# Altium schematic sheet Driver_Led_7seg_matrix.SchDoc(Driver_Led_7seg_matrix)
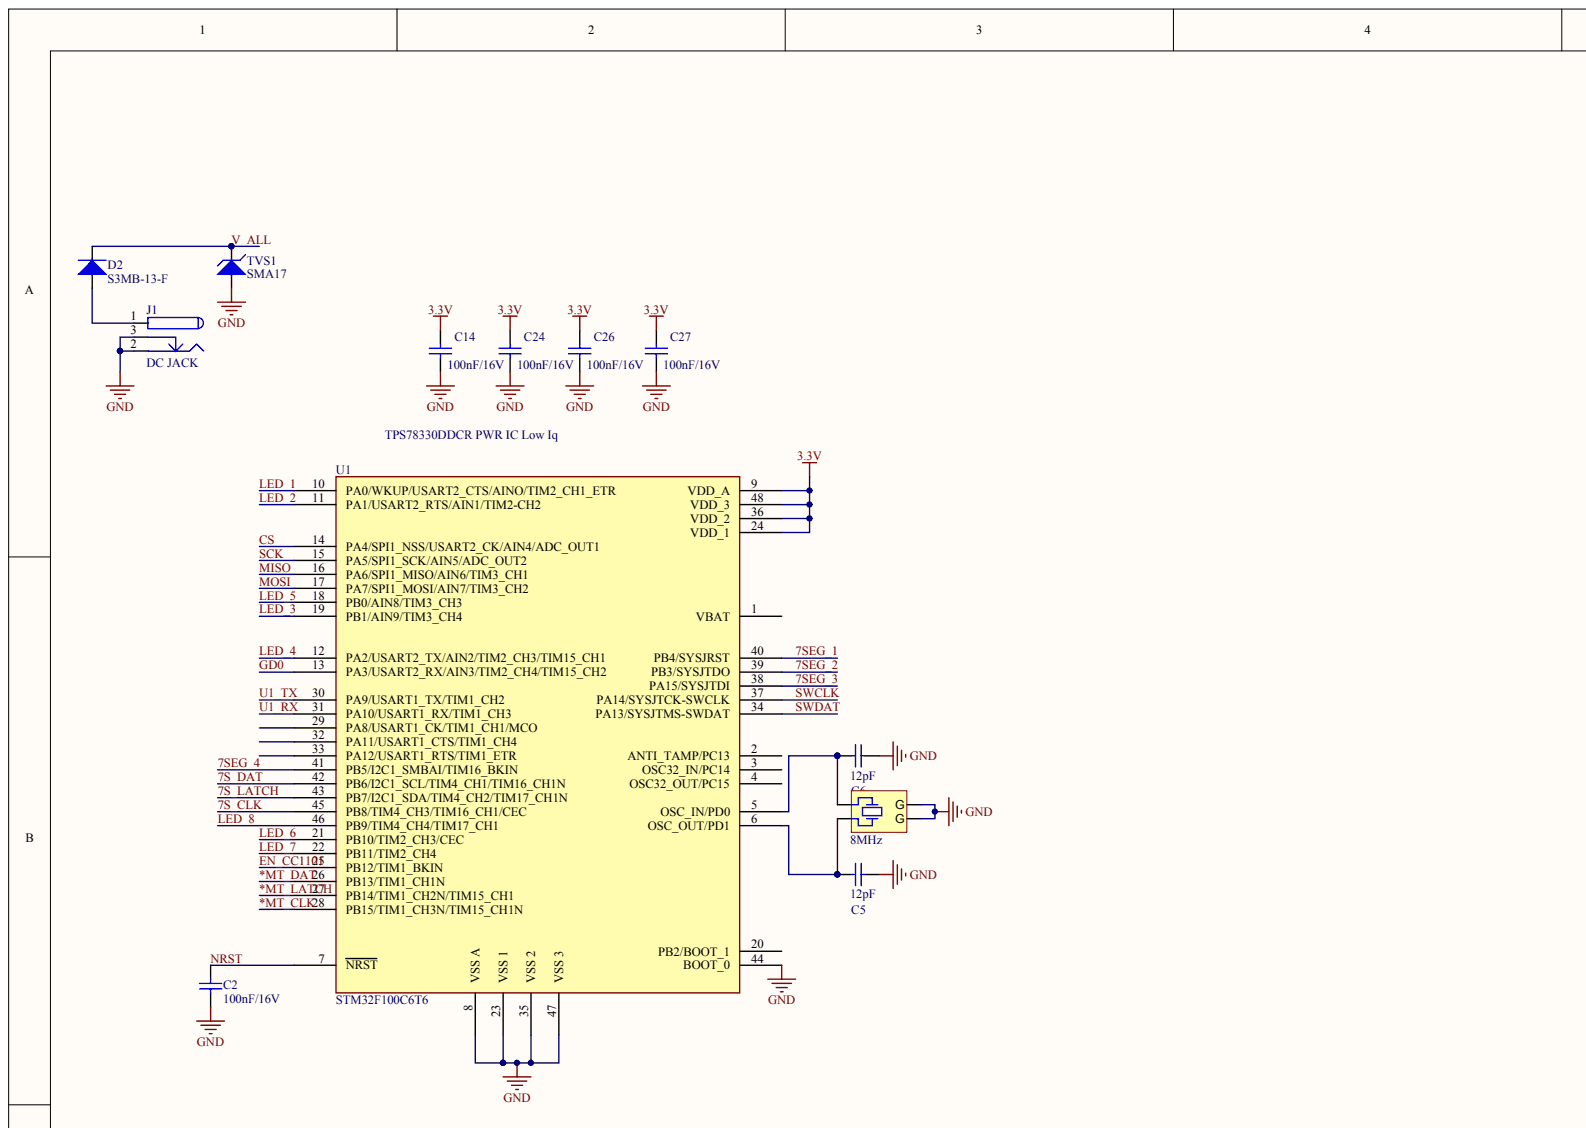
[diagram p0: Driver_Led_7seg_matrix.SchDoc, top left]
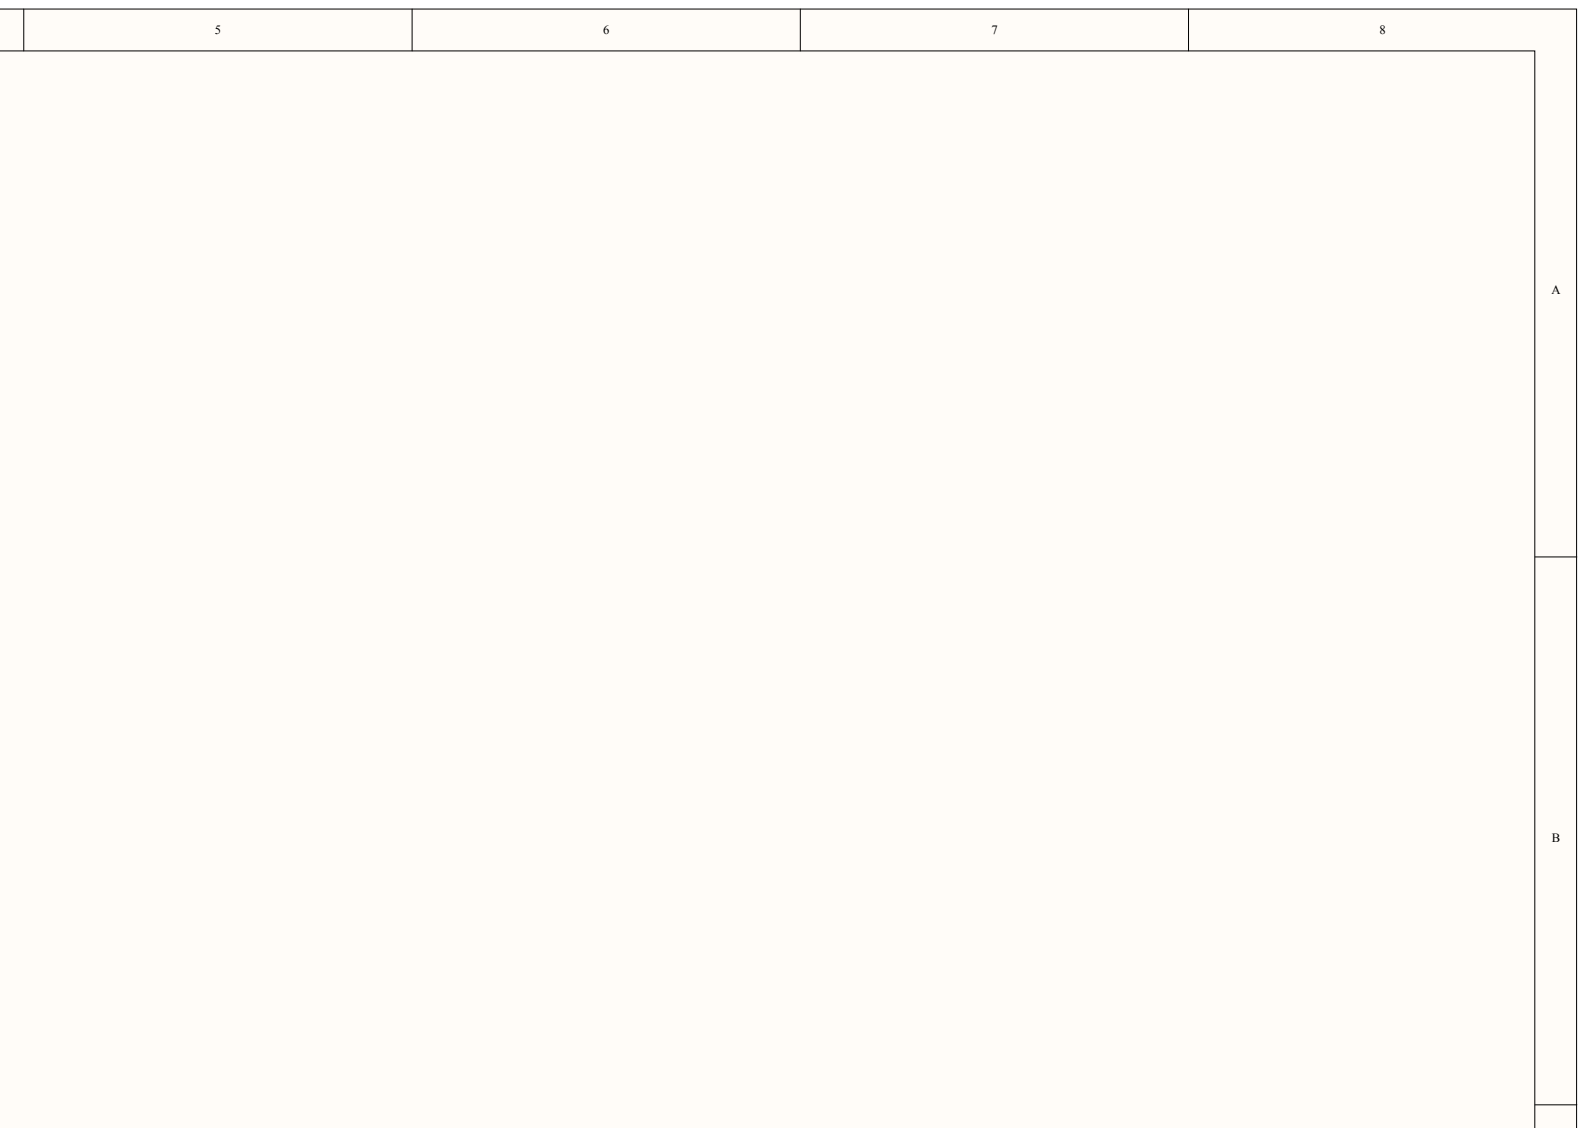
[diagram p1: Driver_Led_7seg_matrix.SchDoc, top right]
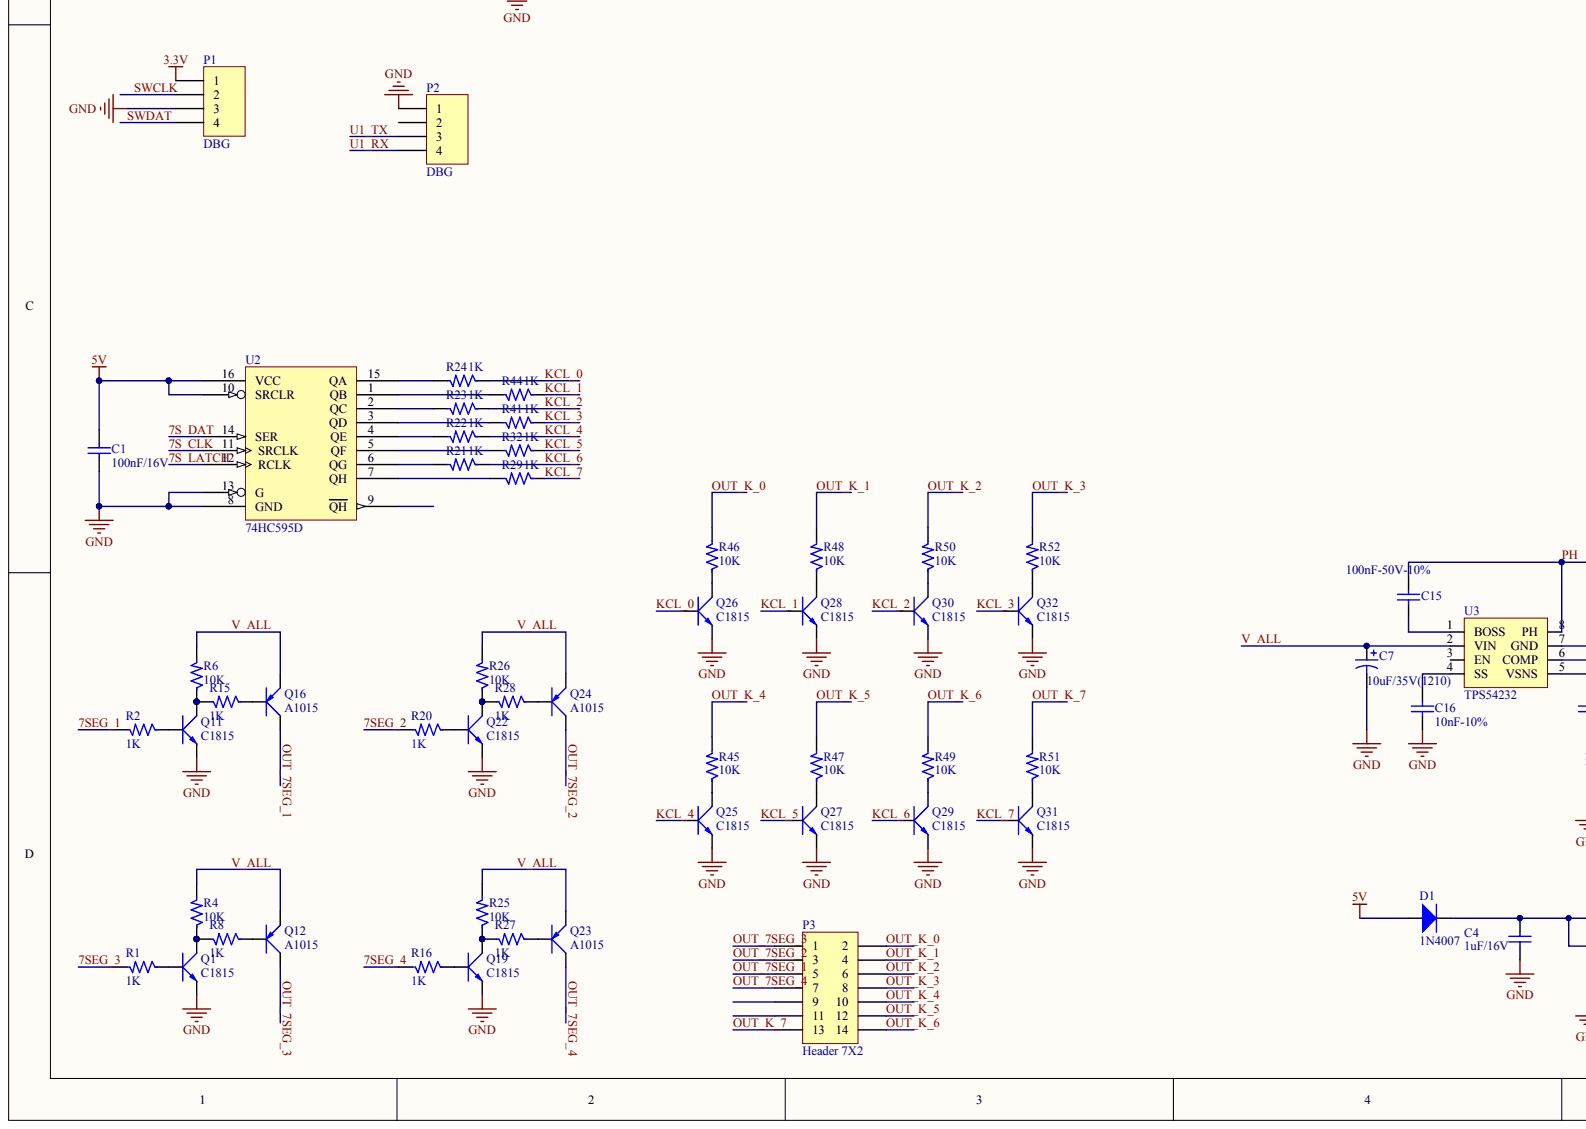
[diagram p2: Driver_Led_7seg_matrix.SchDoc, bottom left]
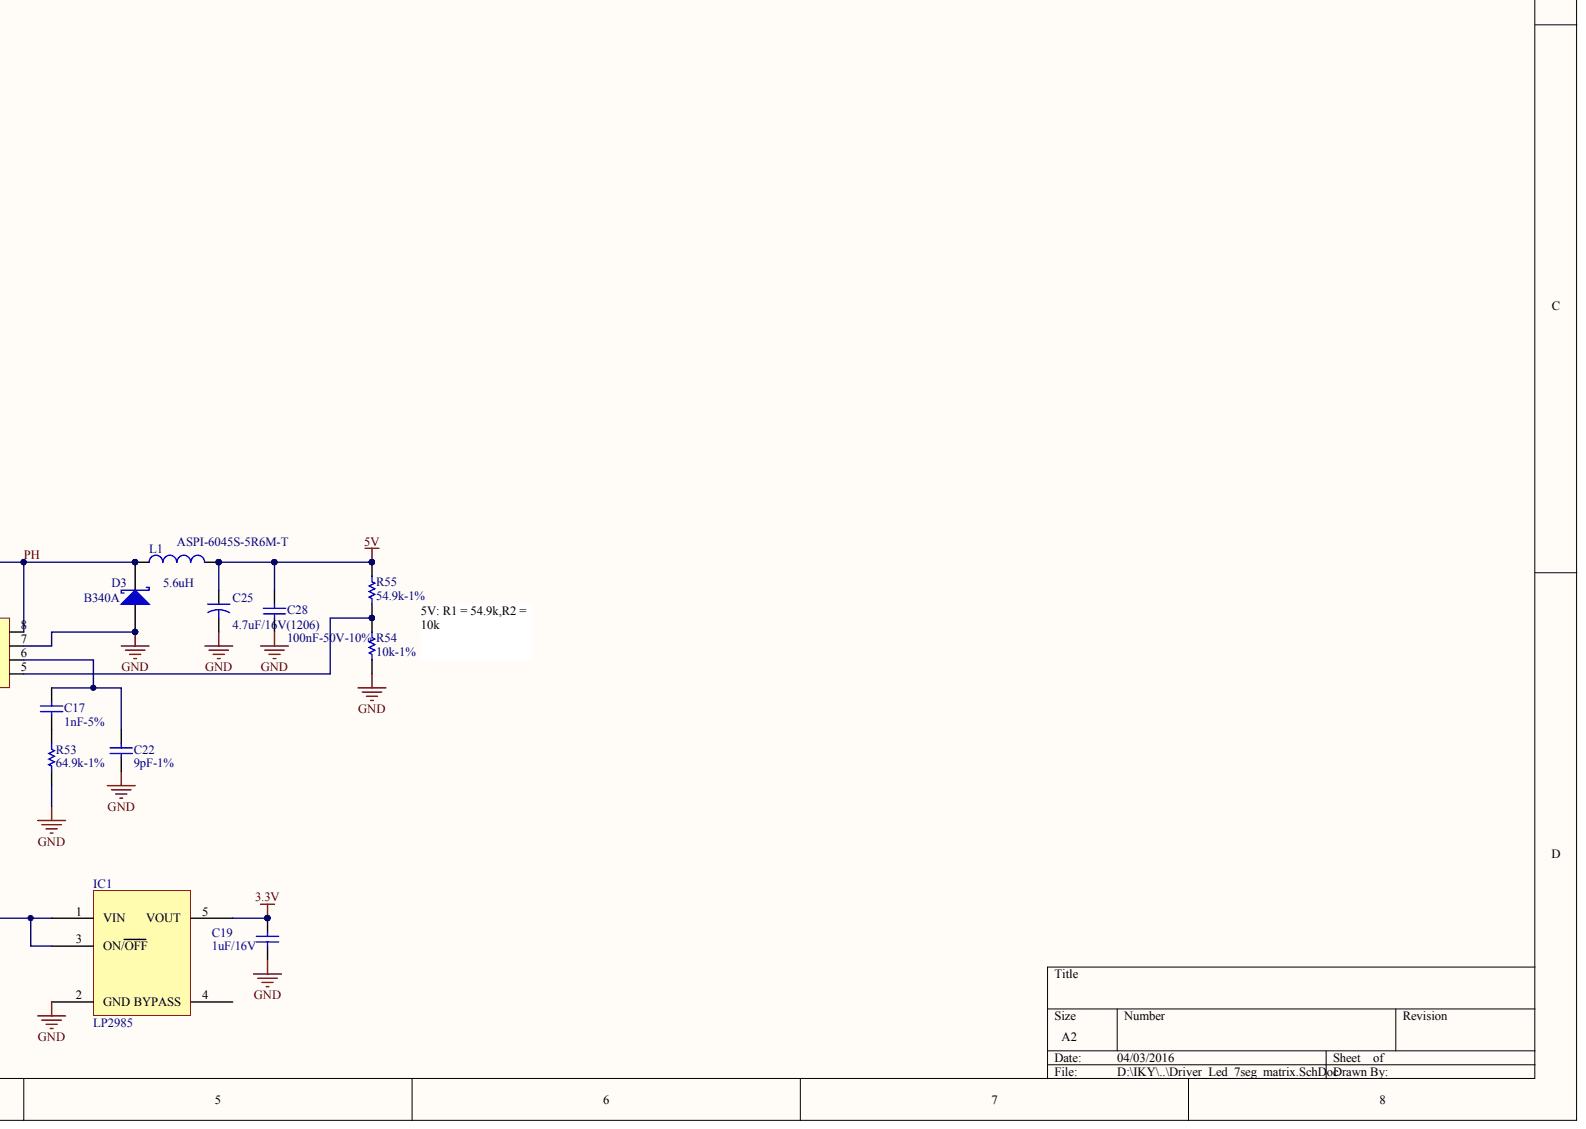
[diagram p3: Driver_Led_7seg_matrix.SchDoc, bottom right]

## Parts
C1  100nF/16V
C2  100nF/16V
C4  1uF/16V
C5  12pF
C6  12pF
C7  10uF/35V(1210)
C14  100nF/16V
C15  100nF  value 100nF-50V-10%
C16  10nF-10%
C17  1nF-5%
C19  1uF/16V
C22  9pF-1%
C24  100nF/16V
C25  4.7uF  value 4.7uF/16V(1206)
C26  100nF/16V
C27  100nF/16V
C28  100nF  value 100nF-50V-10%
D1  1N4007
D2  S3MB-13-F
D3  B340A
IC1  LP2985
J1  DC JACK
L1  ASPI-6045S-5R6M-T  value 5.6uH
P1  DBG
P2  DBG
P3  Header 7X2
Q1  C1815
Q11  C1815
Q12  A1015
Q16  A1015
Q19  C1815
Q22  C1815
Q23  A1015
Q24  A1015
Q25  C1815
Q26  C1815
Q27  C1815
Q28  C1815
Q29  C1815
Q30  C1815
Q31  C1815
Q32  C1815
R1  1K
R2  1K
R4  10K
R6  10K
R8  value 10K  (hidden comment: 1K)
R15  1K  value 10K
R16  1K
R20  1K
R21  1K  (value not drawn)
R22  1K  (value not drawn)
R23  1K  (value not drawn)
R24  1K  (value not drawn)
R25  10K
R26  10K
R27  1K  value 10K
R28  1K  value 10K
R29  1K  (value not drawn)
R32  1K  (value not drawn)
R41  1K  (value not drawn)
R44  1K  (value not drawn)
R45  10K
R46  10K
R47  10K
R48  10K
R49  10K
R50  10K
R51  10K
R52  10K
R53  64.9k-1%
R54  10k-1%
R55  54.9k-1%
TVS1  SMA17
U1  STM32F100C6T6
U2  74HC595D
U3  TPS54232
Y1  8MHz

## Nets
3.3V: C14.1 C19.1 C24.1 C26.1 C27.1 IC1.5(VOUT) P1.1 U1.9(VDD_A) U1.24(VDD_1) U1.36(VDD_2) U1.48(VDD_3)
5V: C1.1 C25.1 C28.2 D1.1 L1.2 R55.2 U2.10(SRCLR) U2.16(VCC)
GND: C1.2 C2.2 C4.2 C5.2 C6.2 C7.2 C14.2 C16.1 C19.2 C22.1 C24.2 C25.2 C26.2 C27.2 C28.1 D3.1 IC1.2(GND) J1.2 J1.3 P1.3 P2.1 Q1.2 Q11.2 Q19.2 Q22.2 Q25.2 Q26.2 Q27.2 Q28.2 Q29.2 Q30.2 Q31.2 Q32.2 R53.1 R54.1 TVS1.1 U1.8(VSS_A) U1.23(VSS_1) U1.35(VSS_2) U1.44(BOOT_0) U1.47(VSS_3) U2.8(GND) U2.13(G) U3.7(GND) Y1.2(G) Y1.4(G)
7S_CLK: U1.45(PB8/TIM4_CH3/TIM16_CH1/CEC) U2.11(SRCLK)
7S_DAT: U1.42(PB6/I2C1_SCL/TIM4_CH1/TIM16_CH1N) U2.14(SER)
7S_LATCH: U1.43(PB7/I2C1_SDA/TIM4_CH2/TIM17_CH1N) U2.12(RCLK)
7SEG_1: R2.2 U1.40(PB4/SYSJRST)
7SEG_2: R20.2 U1.39(PB3/SYSJTDO)
7SEG_3: R1.2 U1.38(PA15/SYSJTDI)
7SEG_4: R16.2 U1.41(PB5/I2C1_SMBAI/TIM16_BKIN)
*MT_CLK: U1.28(PB15/TIM1_CH3N/TIM15_CH1N)
*MT_DAT: U1.26(PB13/TIM1_CH1N)
*MT_LATCH: U1.27(PB14/TIM1_CH2N/TIM15_CH1)
CS: U1.14(PA4/SPI1_NSS/USART2_CK/AIN4/ADC_OUT1)
EN_CC1101: U1.25(PB12/TIM1_BKIN)
GD0: U1.13(PA3/USART2_RX/AIN3/TIM2_CH4/TIM15_CH2)
KCL_0: Q26.1 R24.1
KCL_1: Q28.1 R44.1
KCL_2: Q30.1 R23.1
KCL_3: Q32.1 R41.1
KCL_4: Q25.1 R22.1
KCL_5: Q27.1 R32.1
KCL_6: Q29.1 R21.1
KCL_7: Q31.1 R29.1
LED_1: U1.10(PA0/WKUP/USART2_CTS/AINO/TIM2_CH1_ETR)
LED_2: U1.11(PA1/USART2_RTS/AIN1/TIM2-CH2)
LED_3: U1.19(PB1/AIN9/TIM3_CH4)
LED_4: U1.12(PA2/USART2_TX/AIN2/TIM2_CH3/TIM15_CH1)
LED_5: U1.18(PB0/AIN8/TIM3_CH3)
LED_6: U1.21(PB10/TIM2_CH3/CEC)
LED_7: U1.22(PB11/TIM2_CH4)
LED_8: U1.46(PB9/TIM4_CH4/TIM17_CH1)
MISO: U1.16(PA6/SPI1_MISO/AIN6/TIM3_CH1)
MOSI: U1.17(PA7/SPI1_MOSI/AIN7/TIM3_CH2)
NRST: C2.1 U1.7(NRST)
OUT_7SEG_1: P3.5 Q16.3
OUT_7SEG_2: P3.3 Q24.3
OUT_7SEG_3: P3.1 Q12.3
OUT_7SEG_4: P3.7 Q23.3
OUT_K_0: P3.2 R46.2
OUT_K_1: P3.4 R48.2
OUT_K_2: P3.6 R50.2
OUT_K_3: P3.8 R52.2
OUT_K_4: P3.10 R45.2
OUT_K_5: P3.12 R47.2
OUT_K_6: P3.14 R49.2
OUT_K_7: P3.13 R51.2
PH: C15.1 D3.2 L1.1 U3.8(PH)
SCK: U1.15(PA5/SPI1_SCK/AIN5/ADC_OUT2)
SWCLK: P1.2 U1.37(PA14/SYSJTCK-SWCLK)
SWDAT: P1.4 U1.34(PA13/SYSJTMS-SWDAT)
U1_RX: P2.4 U1.31(PA10/USART1_RX/TIM1_CH3)
U1_TX: P2.3 U1.30(PA9/USART1_TX/TIM1_CH2)
V_ALL: C7.1 D2.2 Q12.2 Q16.2 Q23.2 Q24.2 R4.2 R6.2 R25.2 R26.2 TVS1.2 U3.2(VIN)
NetC4_1: C4.1 D1.2 IC1.1(VIN) IC1.3(ON/OFF)
NetC5_1: C5.1 U1.6(OSC_OUT/PD1) Y1.1
NetC6_1: C6.1 U1.5(OSC_IN/PD0) Y1.3
NetC15_2: C15.2 U3.1(BOSS)
NetC16_2: C16.2 U3.4(SS)
NetC17_1: C17.1 R53.2
NetC17_2: C17.2 C22.2 U3.6(COMP)
NetD2_1: D2.1 J1.1
NetQ1_1: Q1.1 R1.1
NetQ1_3: Q1.3 R4.1 R8.2
NetQ11_1: Q11.1 R2.1
NetQ11_3: Q11.3 R6.1 R15.2
NetQ12_1: Q12.1 R8.1
NetQ16_1: Q16.1 R15.1
NetQ19_1: Q19.1 R16.1
NetQ19_3: Q19.3 R25.1 R27.2
NetQ22_1: Q22.1 R20.1
NetQ22_3: Q22.3 R26.1 R28.2
NetQ23_1: Q23.1 R27.1
NetQ24_1: Q24.1 R28.1
NetQ25_3: Q25.3 R45.1
NetQ26_3: Q26.3 R46.1
NetQ27_3: Q27.3 R47.1
NetQ28_3: Q28.3 R48.1
NetQ29_3: Q29.3 R49.1
NetQ30_3: Q30.3 R50.1
NetQ31_3: Q31.3 R51.1
NetQ32_3: Q32.3 R52.1
NetR21_2: R21.2 U2.6(QG)
NetR22_2: R22.2 U2.4(QE)
NetR23_2: R23.2 U2.2(QC)
NetR24_2: R24.2 U2.15(QA)
NetR29_2: R29.2 U2.7(QH)
NetR32_2: R32.2 U2.5(QF)
NetR41_2: R41.2 U2.3(QD)
NetR44_2: R44.2 U2.1(QB)
NetR54_2: R54.2 R55.1 U3.5(VSNS)

Not connected: IC1 4(BYPASS); P2 2; P3 9 11; U1 1(VBAT) 2(ANTI_TAMP/PC13) 3(OSC32_IN/PC14) 4(OSC32_OUT/PC15) 20(PB2/BOOT_1) 29(PA8/USART1_CK/TIM1_CH1/MCO) 32(PA11/USART1_CTS/TIM1_CH4) 33(PA12/USART1_RTS/TIM1_ETR); U2 9(QH); U3 3(EN)

## Library data of the parts
C1 C2 C4-C6 C14 C19 C24 C26 C27 = (no symbol name): Manufacturer: Yageo; Value: 100nF/50V; Unit Price: *; Manufacturer P/N: *; PackageReference: *; Digi-Key P/N: *; Mouser P/N: *; Vender: *; Publisher: Cao Van Huong, vanhuongcao@gmail.com
C7 = (no symbol name): Manufacturer: AVX Corporation; Manufacturer Part Number: TAJC106M035RNJ; Value: 10uF-35V; Digi-Key Part Number: 478-4973-1-ND; Unit Price: 1.3
C16 = (no symbol name): Manufacturer: Yageo; Manufacturer Part Number: CC0805KRX7R9BB103; Value: 10nF-10%; Digi-Key Part Number: 311-1136-1-ND; Unit Price: 0.1
C17 = (no symbol name): Manufacturer: Yageo; Manufacturer Part Number: CC0805JRNPO9BN102; Value: 1nF-5%; Digi-Key Part Number: 311-1122-1-ND; Unit Price: 0.18
C22 = (no symbol name): Manufacturer: Yageo; Manufacturer Part Number: CC0805DRNPO9BN9R0; Value: 9pF; Digi-Key Part Number: 311-1098-1-ND; Unit Price: 0.1
D1 = (no symbol name): Manufacturer P/N: 1N4007-E3/54; Manufacturer: Vishay Semiconductors; Unit Price: *; Value: 1N4007; PackageReference: SOD123; Digi-Key P/N: *; Mouser P/N: 625-1N4007-E3/73; Vender: *; Publisher: Cao Van Huong, vanhuongcao@gmail.com
D2 = (no symbol name): Manufacturer P/N: 1N4007-E3/54; Manufacturer: Vishay Semiconductors; Value: 1N4007; Unit Price: *; PackageReference: SOD123; Digi-Key P/N: *; Mouser P/N: 625-1N4007-E3/73; Vender: *; Publisher: Cao Van Huong, vanhuongcao@gmail.com
D3 = (no symbol name): Manufacturer Part Number: B340A-E3/61T; Manufacturer: Vishay General Semiconductor; Published: 8-Jun-2000; LatestRevisionDate: 17-Jul-2002; LatestRevisionNote: Re-released for DXP Platform.; PackageReference: DO-214-AA; Code_JEDEC: DO-214-AA; Unit Price: 0.15840; Digi-Key Part Number: B340A-E3/61TGITR-ND; Publisher: Altium Limited
IC1 = (no symbol name): Manufacturer: Microchip; Manufacturer P/N: MCP73831T-2ACI/OT; Value: MCP73831T; Unit Price: *; PackageReference: SOT23-5; Digi-Key P/N: *; Mouser P/N: *; Vender: *; Publisher: Cao Van Huong, vanhuongcao@gmail.com
L1 = (no symbol name): Manufacturer: Abracon Corporation; Code_IEC: 1005; Digi-Key Part Number: ASPI-6045S-5R6M-TTR-ND; LatestRevisionDate: 29-May-2009; LatestRevisionNote: IPC-7351 Footprint Added.; PackageReference: 0402-A; PackageDescription: Chip Inductor; Code_JEITA: 0402; Manufacturer Part Number: ASPI-6045S-5R6M-T; Unit Price: 0.21000; Publisher: Altium Limited
R1 R2 R16 R20-R24 R29 R32 R41 R44 = (no symbol name): Manufacturer: Yageo; Value: 10K; Manufacturer P/N: *; Unit Price: *; PackageReference: R0805; Digi-Key P/N: *; Mouser P/N: *; Vender: *; Publisher: Cao Van Huong, vanhuongcao@gmail.com
R4 R6 R8 R15 R25-R28 R45-R52 = (no symbol name): Manufacturer: Yageo; Manufacturer P/N: *; Unit Price: *; PackageReference: R0805; Digi-Key P/N: *; Mouser P/N: *; Vender: *; Publisher: Cao Van Huong, vanhuongcao@gmail.com
  R4 R6 R25 R26 R45-R52: Value: 10K
R53 = (no symbol name): Manufacturer: Yageo; Manufacturer Part Number: RC0603FR-0764K9L; Digi-Key Part Number: 311-64.9KHRTR-ND; Unit Price: 0.00171
R54 = (no symbol name): Manufacturer: Yageo; Manufacturer Part Number: RC0603FR-0710KL; Digi-Key Part Number: 311-10.0KHRTR-ND; Unit Price: 0.00171
R55 = (no symbol name): Manufacturer: Yageo; Manufacturer Part Number: RC0603FR-0754K9L; Digi-Key Part Number: 311-54.9KHRTR-ND; Unit Price: 0.00171
TVS1 = (no symbol name): Manufacturer P/N: SM15T15A-E3/9AT; Manufacturer: Vishay Semiconductors; Value: SM15T15A; Unit Price: *; PackageReference: DO-214AA; Digi-Key P/N: *; Mouser P/N: 625-SM15T15A-E3/9AT; Vender: *; Publisher: Cao Van Huong, vanhuongcao@gmail.com
U1 = (no symbol name): Manufacturer: STMicroelectronics; Manufacturer P/N: STM32F100C6T6BXXX; Value: STM32F100C6T6; Unit Price: *; PackageReference: LQFP48; Digi-Key P/N: *; Mouser P/N: *; Vender: *; Publisher: Cao Van Huong, vanhuongcao@gmail.com
C15 C25 C28 J1 P1-P3 Q1 Q11 Q12 Q16 Q19 Q22-Q32 U2 U3 Y1 = (no symbol name)
  C15 C28: Published: 8-Jun-2000; LatestRevisionDate: 17-Jul-2002; LatestRevisionNote: Re-released for DXP Platform.; PackageReference: RAD-0.3
  C25: Published: 23-Sep-2002; PackageReference: CAPR5-4X5
  J1: Value: *; Digi-Key P/N: *; Mouser P/N: *; Vender: *
  P3: LatestRevisionDate: 17-Jul-2002; LatestRevisionNote: Re-released for DXP Platform.
  Q1 Q11 Q19 Q22 Q25-Q32: Manufacturer P/N: *; Value: *; Manufacturer: *; Unit Price: *; PackageReference: *; Digi-Key P/N: *; Mouser P/N: *; Vender: *
  Q12 Q16 Q23 Q24: Manufacturer: *; Manufacturer P/N: *; Unit Price: *; PackageReference: *; Value: *; Digi-Key P/N: *; Mouser P/N: *; Vender: *
  U2: Manufacturer P/N: *; Manufacturer: *; Unit Price: *; PackageReference: *; Value: *; Digi-Key P/N: *; Mouser P/N: *; Vender: *
  Y1: Unit Price: *; Manufacturer P/N: *; Manufacturer: *; PackageReference: *; Digi-Key P/N: *; Mouser P/N: *; Vender: *; Publisher: *; Publisher: Cao Van Huong, vanhuongcao@gmail.com
  C15 C25 C28 P3: Publisher: Altium Limited
  J1 Q1 Q11 Q12 Q16 Q19 Q22-Q32 U2: Publisher: Cao Van Huong, vanhuongcao@gmail.com
